# Altium schematic sheet Rev_C_Power.SchDoc
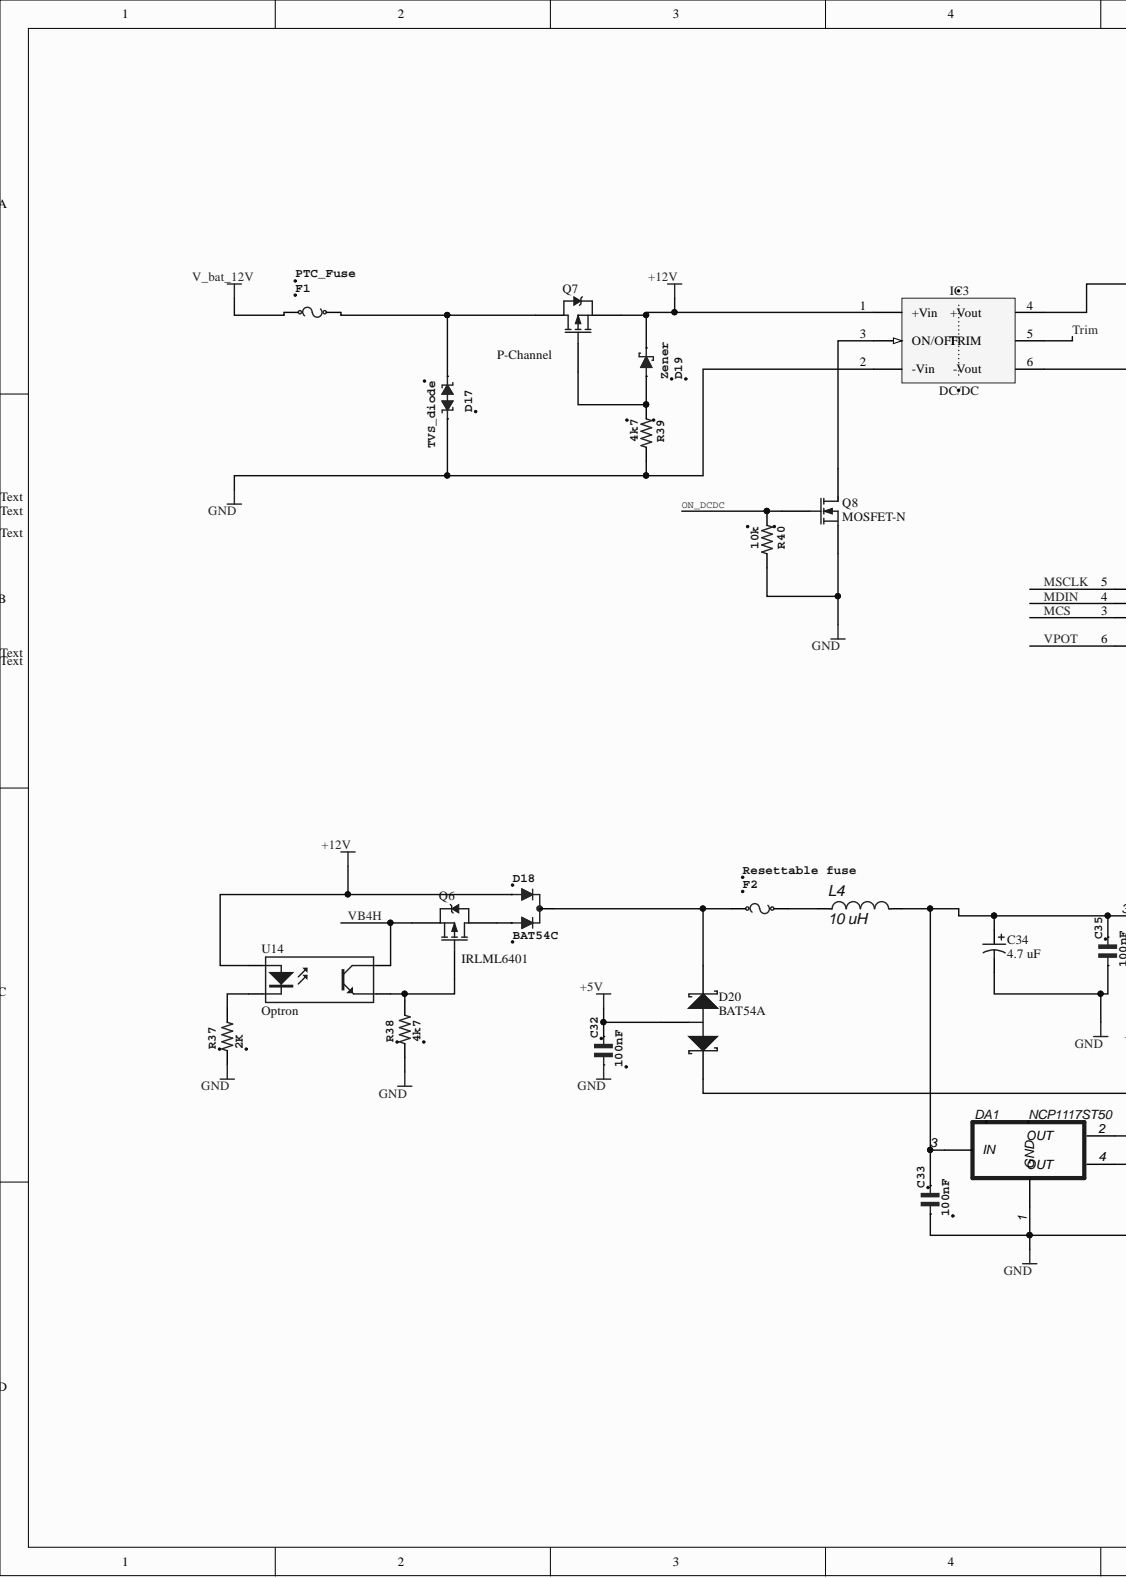
[diagram p0: Rev_C_Power.SchDoc, left]
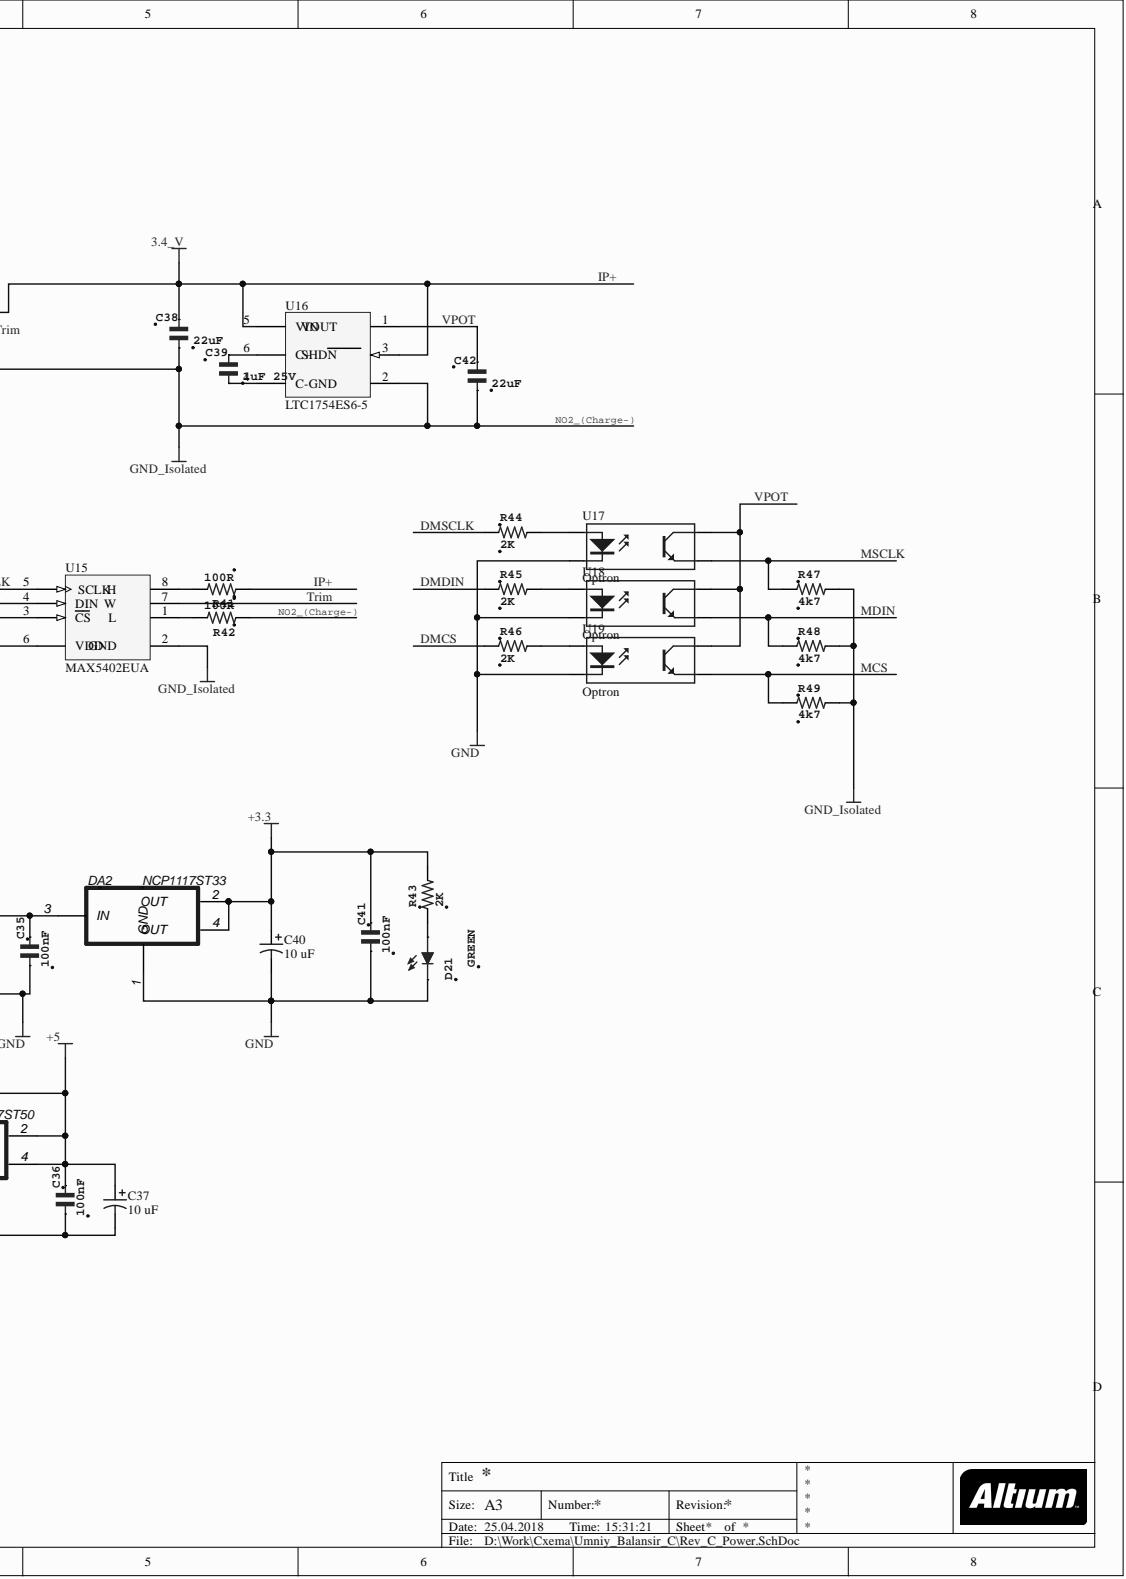
[diagram p1: Rev_C_Power.SchDoc, right]

## Parts
C32  100nF  [C0603]
C33  100nF  [C0603]
C34  4.7 uF  [SMD D]
C35  100nF  [C0603]
C36  100nF  [C0603]
C37  10 uF  [SMD A]
C38  22uF  [C0603]
C39  1uF 25V  [C0603]
C40  10 uF  [SMD A]
C41  100nF  [C0603]
C42  22uF  [C0603]
D17  TVS_diode  [SMB_BIDIR]
D18  BAT54C  [SOT23]
D19  Zener  [SOD523]
D20  BAT54A  [SOT23_N]
D21  GREEN  [CHIP-LED0603]
DA1  NCP1117ST50  [SOT223]
DA2  NCP1117ST33  [SOT223]
F1  PTC_Fuse  [RUEF250]
F2  Resettable fuse  [R1206]
IC3  DC/DC  [DCDC_1x1]
L4  10 uH  [C0603]
Q6  IRLML6401  [SOT23-3L]
Q7  P-Channel  [SO-8 - IRF FET]
Q8  MOSFET-N  [SOT23-3N]
R37  2K  [R0603]
R38  4k7  [R0603]
R39  4k7  [R0603]
R40  10k  [R0603]
R41  100R  [R0603]
R42  100R  [R0603]
R43  2K  [R0603]
R44  2K  [R0603]
R45  2K  [R0603]
R46  2K  [R0603]
R47  4k7  [R0603]
R48  4k7  [R0603]
R49  4k7  [R0603]
U14  Optron  [LTV-816S]
U15  MAX5402EUA  [MAXM-U8-1_N]
U16  LTC1754ES6-5  [LT-S6-6-SOT-23_N]
U17  Optron  [LTV-816S]
U18  Optron  [LTV-816S]
U19  Optron  [LTV-816S]

## Nets
+3.3: C40.1 C41.2 DA2.2 DA2.4 R43.2
+5: C36.2 C37.1 D20.1 DA1.2 DA1.4
+5V: C32.2 D20.3
+12V: D18.1 D19.C IC3.1(+Vin) Q7.1 U14.1
GND: C32.1 C33.1 C34.2 C35.1 C36.1 C37.2 C40.2 C41.1 D17.2 D21.C DA1.1(GND) DA2.1(GND) IC3.2(-Vin) Q8.2 R37.1 R38.1 R39.2 R40.2 U17.2 U18.2 U19.2
V_BAT_12V: F1.1
DMCS: R46.1
DMDIN: R45.1
DMSCLK: R44.1
IP+: C38.2 IC3.4 R41.1 U16.3 U16.5(VIN)
MCS: R49.1 U15.3(CS) U19.3
MDIN: R48.1 U15.4(DIN) U18.3
MSCLK: R47.1 U15.5(SCLK) U17.3
NO2_(CHARGE-): C38.1 C42.1 IC3.6 R42.1 R47.2 R48.2 R49.2 U15.2 U16.2
ON_DCDC: Q8.1 R40.1
TRIM: IC3.5 U15.7
VB4H: Q6.2 U14.4
VPOT: C42.2 U15.6(VDD) U16.1 U17.4 U18.4 U19.4
NetC33_2: C33.2 C34.1 C35.2 DA1.3(IN) DA2.3(IN) L4.2
NetC39_1: C39.1 U16.4(C-_GND)
NetC39_2: C39.2 U16.6(C+)
NetD17_1: D17.1 F1.2 Q7.3
NetD18_2: D18.2 Q6.3
NetD18_3: D18.3 D20.2 F2.1
NetD19_A: D19.A Q7.2 R39.1
NetD21_A: D21.A R43.1
NetF2_2: F2.2 L4.1
NetIC3_3: IC3.3(ON/OFF) Q8.3
NetQ6_1: Q6.1 R38.2 U14.3
NetR37_2: R37.2 U14.2
NetR41_2: R41.2 U15.8
NetR42_2: R42.2 U15.1
NetR44_2: R44.2 U17.1
NetR45_2: R45.2 U18.1
NetR46_2: R46.2 U19.1

## Library data of the parts
C32 C33 C35 C36 C41 = C_0603: GRM188R71E104KA01J; MPN: GRM188F51E104ZA01D; MF: MURATA; Component Kind: Standard; OC_FARNELL: 1828902; Value: 100nF; Library Name: balan_1.SCHLIB
C34 = Cap Pol2: 293D475X9050D2WE3; Component Kind: Standard; LatestRevisionDate: 17-Jul-2002; LatestRevisionNote: Re-released for DXP Platform.; Library Name: ev-board_1.SchLib; PackageDescription: Polarized Capacitor; 2 Leads; PackageReference: POLAR0.8; Published: 8-Jun-2000; Value: 4.7 uF; Publisher: Altium Limited
C37 C40 = Cap Pol2: THJA106K010AJN; Component Kind: Standard; LatestRevisionDate: 17-Jul-2002; LatestRevisionNote: Re-released for DXP Platform.; Library Name: ev-board_1.SchLib; PackageDescription: Polarized Capacitor; 2 Leads; PackageReference: POLAR0.8; Published: 8-Jun-2000; Value: 10 uF; Publisher: Altium Limited
C38 C42 = C_0603: ZRB18AR61A226ME01L; MF: MURATA; Component Kind: Standard; Value: 22uF; Library Name: balan_1.SCHLIB
C39 = C_0603: 885012106022; MF: MURATA; Component Kind: Standard; Value: 1uF 25V; Library Name: balan_1.SCHLIB
D17 = DIODE-TVS-SMAJ_1: SMAJ17CA; Component Kind: Standard; Library Name: ev-board_1.SchLib
D18 = BAT54C: BAT54C-G; Component Kind: Standard; Library Name: ev-board_1.SchLib; OC_FARNELL: unknown
D19 = SCHOTTKY-DIODESMA_2: BZT52C10T-7; Component Kind: Standard; Library Name: ev-board_1.SchLib
D20 = BAT54A: TBAT54A,LM; Component Kind: Standard; ComponentLink1Description: Manufacturer Link; ComponentLink1URL: http://www.semiconductors.philips.com/; ComponentLink2Description: Datasheet; ComponentLink2URL: http://www.semiconductors.philips.com/acrobat/datasheets/BAT54_SERIES_4.pdf; DatasheetVersion: 4-Mar-2002; LatestRevisionDate: 12-Dec-2006; LatestRevisionNote: IPC-7351 Footprint Added.; Library Name: ev-board_1.SchLib; PackageDescription: 3-Pin SOT-23 Package 0.95 mm Pitch, 2.3 mm Lead Span; PackageReference: SOT23; PackageVersion: May-1999; Published: 17-Sep-2003; Publisher: Altium Limited
D21 = LEDCHIP-LED0603_2: 150060VS75000; Manufacturer Part Number: 150060RS75000; BLUE: 150060BS75000; YELLOW: 150060YS75000; Component Kind: Standard; GREEN: 150060VS75000; Library Name: ev-board_1.SchLib; RED: 150060RS75000; Value: GREEN
DA1 = NCP1117ST50: NCP1117LPST50T3G; Title: stabilizer; Component Kind: Standard; Library Name: X_Bee.SCHLIB
DA2 = NCP1117ST33: NCP1117LPST33T3G; Title: stabilizer; Component Kind: Standard; Library Name: X_Bee.SCHLIB
F1 = RUEF250: Value: 9A; Component Kind: Standard; Description: RUEF250; Library Name: ev-board_1.SchLib
F2 = 3216CP-3A_1: 0ZCJ0050AF2E; Value: 500mA; Component Kind: Standard; Library Name: ev-board_1.SchLib
IC3 = DCDC_TDK_30_Single Output: PDQ30-Q24-S3-D; Component Kind: Standard; Library Name: ev-board_1.SchLib
L4 = L2: KLZ1608MHR100WTD25; group: Индуктивность; list: #NAME?; sheet: 10 uH tollerance; Component Kind: Standard; Library Name: Passive.IntLib; value: 10 uH
Q6 = IRLML6402: IRLML6401TRPBF; Component Kind: Standard; Library Name: ev-board_1.SchLib
Q7 = PMOS-2: FDS6681Z; Note: Pin 4 not drawn and electrically connected to Pin 2.; Component Kind: Standard; ComponentLink1Description: Manufacturer Link; ComponentLink1URL: http://www.irf.com/; DatasheetVersion: PD-9.390D; LatestRevisionDate: 17-Jul-2002; LatestRevisionNote: Re-released for DXP Platform.; Library Name: ev-board_1.SchLib; PackageDescription: TO, Thru-Hole, Vertical, Heatsink Mounted; 3 In-Line Leads; Pitch 2.54 mm; PackageReference: TO-220AB; PackageVersion: Feb-1997; Published: 8-Jun-2000; Publisher: Altium Limited
Q8 = MOSFET-N: NTR4170NT1G; Component Kind: Standard; LatestRevisionDate: 17-Jul-2002; LatestRevisionNote: Re-released for DXP Platform.; PackageDescription: TO, Flat Index; 3 In-Line, Axial Leads; Body Dia. 4.6mm; Lead Dia. 0.5 mm (max); PackageReference: E3; PackageVersion: 1995/1996; Published: 8-Jun-2000; Library Name: balan_1.SCHLIB; Publisher: Altium Limited
R37 R43-R46 = R_0603_18: RC0603FR-072KL; Component Kind: Standard; Library Name: ev-board_1.SchLib; Manufacturer Part Number: RC0603FR-072KL; OC_FARNELL: unknown; Value: 2K
R38 R39 R47-R49 = R_0603_18: RC0603FR-074K7L; Component Kind: Standard; Library Name: ev-board_1.SchLib; Manufacturer Part Number: RC0603FR-074K7L; OC_FARNELL: unknown; Value: 4k7
R40 = R_0603_18: RC0603FR-0710KL; Component Kind: Standard; Library Name: ev-board_1.SchLib; Manufacturer Part Number: RC0603FR-0710KL; OC_FARNELL: unknown; Value: 10k
R41 R42 = R_0603_18: RC0603FR-07100RL; Component Kind: Standard; Library Name: ev-board_1.SchLib; Manufacturer Part Number: RC0603FR-07100RL; OC_FARNELL: unknown; Value: 100R
U14 U17-U19 = Optoisolator1: LTV-816S; Component Kind: Standard; DatasheetVersion: Nov-2003; LatestRevisionDate: 04-Mar-2005; LatestRevisionNote: Sch pins 3 & 4 Swapped.; Library Name: ev-board_1.SchLib; PackageReference: DIP-4; Published: 24-Mar-1999; Publisher: Altium Limited
U15 = MAX5402EUA: MAX5402EUA+; Control Interface: 3-Wire Serial; Code_JEDEC: MO-187; Component Kind: Standard; ComponentLink1Description: Manufacturer URL; ComponentLink1URL: http://www.maximintegrated.com/; ComponentLink2Description: Datasheet; ComponentLink2URL: http://datasheets.maximintegrated.com/en/ds/MAX5402.pdf; ComponentLink3Description: Package Specification; ComponentLink3URL: http://pdfserv.maximintegrated.com/package_dwgs/21-0036.PDF; DatasheetVersion: Rev. 0; Library Name: ev-board_1.SchLib; Mounting Technology: Surface Mount; PackageDescription: 8-Pin Micro-MAX, Thin Shrink Small-Outline (U8-1), Body 3 x 3 mm, Pitch 0.65mm; PackageReference: U8-1; PackageVersion: Rev. L, 08/2009; PartNumber: MAX5402EUA; Supplier 1: Digi-Key; Supplier 2: Mouser; Supplier Part Number 1: MAX5402EUA-ND; Supplier Part Number 2: N/A
U16 = LTC1754ES6-5: Manufacturer: Linear Technology; Frequency(kHz): 700; Ishutdown(uA): 0.01; Isupply(mA): 0.013; Max Iout(mA): 40; Vin Max(V): 5.5; Vin Min(V): 2.7; Voutput: 5V; Component Kind: Standard; ComponentLink1Description: Manufacturer URL; ComponentLink1URL: http://www.linear.com/; ComponentLink2Description: Datasheet; ComponentLink2URL: http://cds.linear.com/docs/en/datasheet/175435f.pdf; ComponentLink3Description: Package Specification; ComponentLink3URL: http://cds.linear.com/docs/en/datasheet/175435f.pdf; Description: LTC1754ES6-5; Library Name: ev-board_1.SchLib; Mounting Technology: Surface Mount; PackageDescription: 6-Pin Plastic SOT-23, Body 1.625 x 2.9 mm, Pitch 0.95 mm; PackageReference: S6-6; PackageVersion: Aug-07; PartNumber: LTC1754ES6-5; RoHS: FALSE
